# Altium schematic sheet RX_sys.SchDoc(RX_sys)
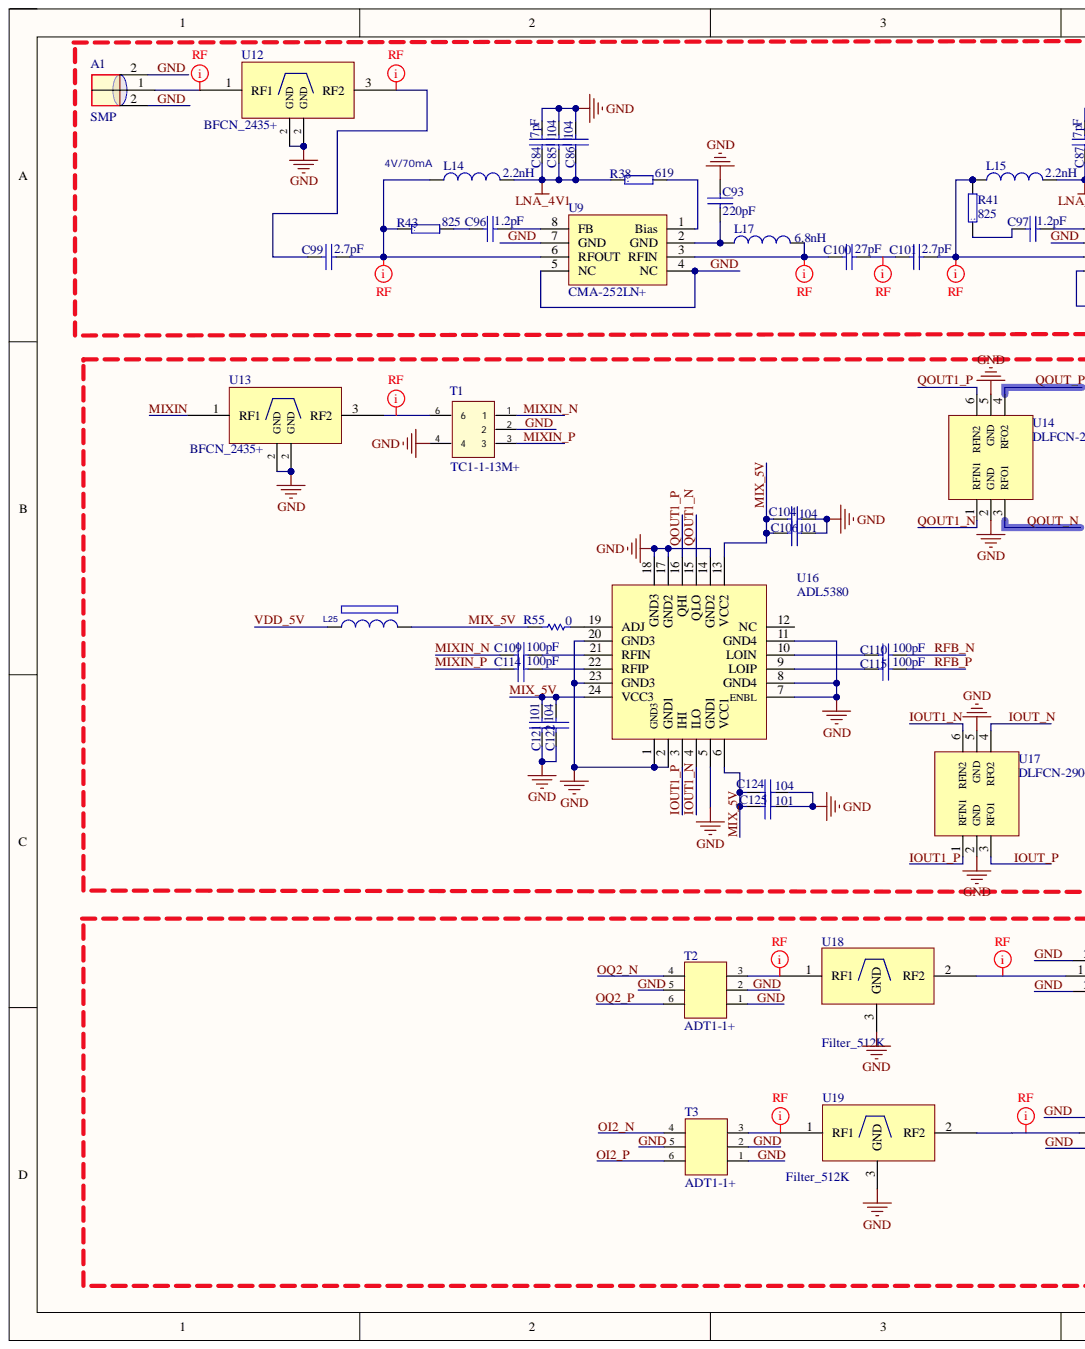
[diagram p0: RX_sys.SchDoc, left]
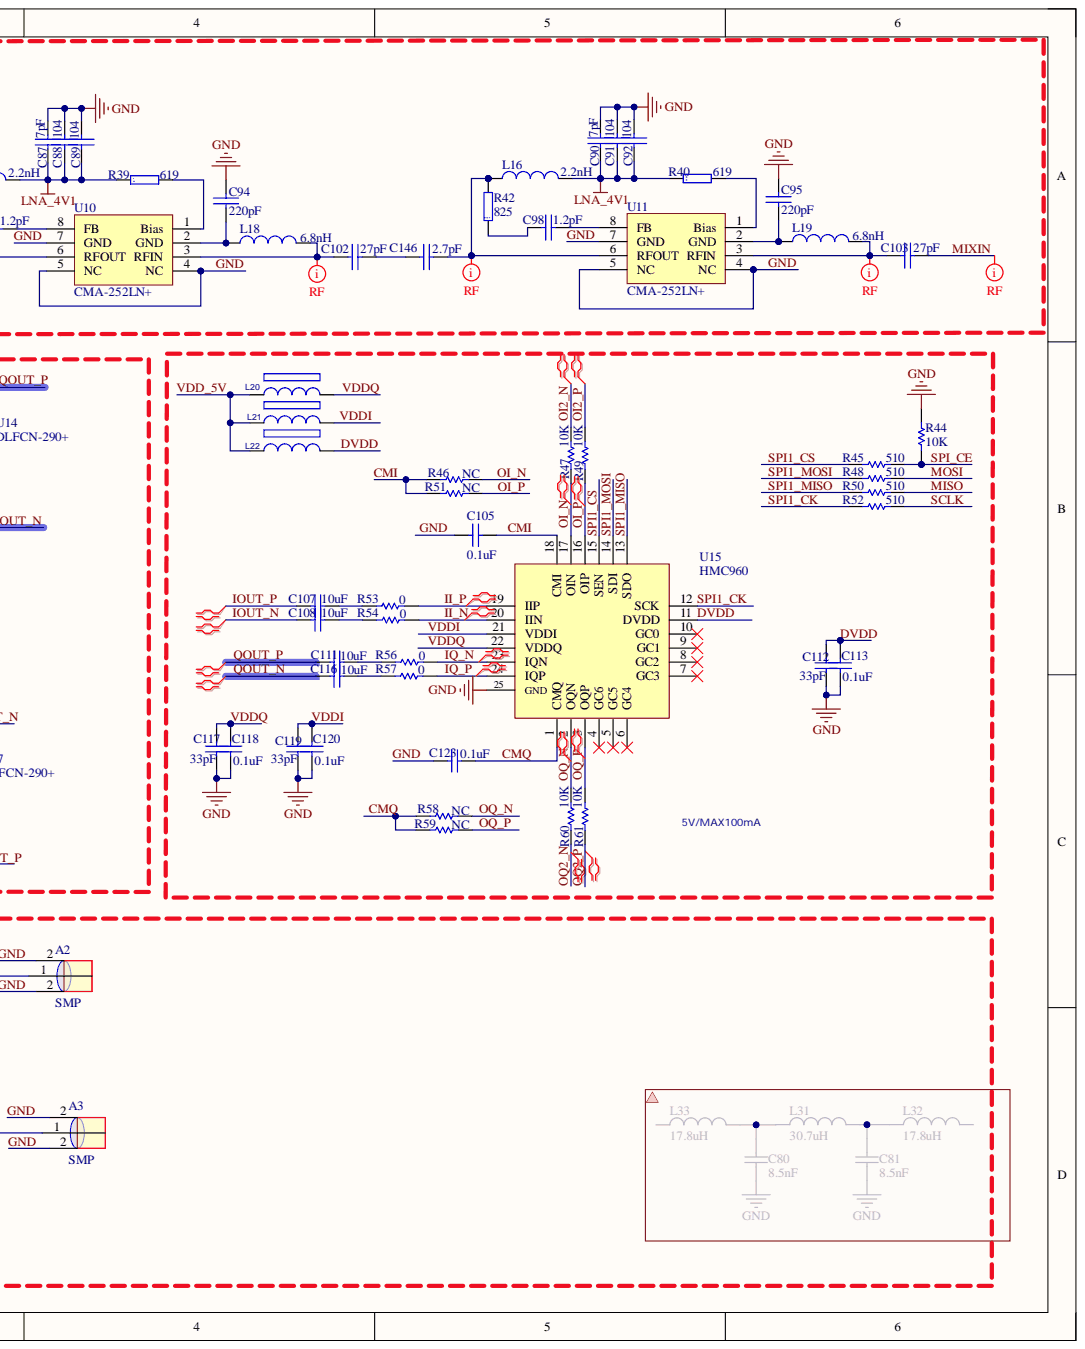
[diagram p1: RX_sys.SchDoc, right]

## Parts
A1  SMP  [SMA_3P]
A2  SMP  [SMA_3P]
A3  SMP  [SMA_3P]
C84  7pF  [0402C]
C85  104  [0402C]
C86  104  [0402C]
C87  7pF  [0402C]
C88  104  [0402C]
C89  104  [0402C]
C90  7pF  [0402C]
C91  104  [0402C]
C92  104  [0402C]
C93  220pF  [0402C]
C94  220pF  [0402C]
C95  220pF  [0402C]
C96  1.2pF  [0402C]
C97  1.2pF  [0402C]
C98  1.2pF  [0402C]
C99  2.7pF  [0402C]
C100  27pF  [0402C]
C101  2.7pF  [0402C]
C102  27pF  [0402C]
C103  27pF  [0402C]
C104  104  [0402C]
C105  0.1uF  [0402C]
C106  101  [0402C]  (value not drawn)
C107  10uF  [0402C]
C108  10uF  [0402C]
C109  100pF  [0402C]
C110  100pF  [0402C]
C111  10uF  [0402C]
C112  33pF  [0402C]
C113  0.1uF  [0402C]
C114  100pF  [0402C]
C115  100pF  [0402C]
C116  10uF  [0402C]
C117  33pF  [0402C]
C118  0.1uF  [0402C]
C119  33pF  [0402C]
C120  0.1uF  [0402C]
C121  101  [0402C]
C122  104  [0402C]
C123  0.1uF  [0402C]
C124  104  [0402C]
C125  101  [0402C]
C146  2.7pF  [0402C]
L14  2.2nH  [0402_L]
L15  2.2nH  [0402_L]
L16  2.2nH  [0402_L]
L17  6.8nH  [0402_L]
L18  6.8nH  [0402_L]
L19  6.8nH  [0402_L]
L20  BLM15HG102SN1D  [0603L]  (value not drawn)
L21  BLM15HG102SN1D  [0603L]  (value not drawn)
L22  BLM15HG102SN1D  [0603L]  (value not drawn)
L25  BLM15HG102SN1D  [0603L]  (value not drawn)
R38  619  [0402R]
R39  619  [0402R]
R40  619  [0402R]
R41  825  [0402R]
R42  825  [0402R]
R43  825  [0402R]
R44  10K  [0402R]
R45  510  [0402R]
R46  NC  [0402R]
R47  10K  [0402R]
R48  510  [0402R]
R49  10K  [0402R]
R50  510  [0402R]
R51  NC  [0402R]
R52  510  [0402R]
R53  0  [0402R]
R54  0  [0402R]
R55  0  [0402R]
R56  0  [0402R]
R57  0  [0402R]
R58  NC  [0402R]
R59  NC  [0402R]
R60  10K  [0402R]
R61  10K  [0402R]
T1  TC1-1-13M+  [TC1-1-43A+]
T2  ADT1-1+  [ADT1-1+]
T3  ADT1-1+  [ADT1-1+]
U9  CMA-252LN+  [CMA-252LN+]
U10  CMA-252LN+  [CMA-252LN+]
U11  CMA-252LN+  [CMA-252LN+]
U12  BFCN_2435+  [BFCN-2435+]
U13  BFCN_2435+  [BFCN-2435+]
U14  DLFCN-290+  [DLFCN-290]
U15  HMC960  [QFN24 _MMIC]
U16  ADL5380  [QFN24 _MMIC]
U17  DLFCN-290+  [DLFCN-290]
U18  Filter_512K  [Filter_512K]
U19  Filter_512K  [Filter_512K]

## Nets
LNA_4V1: C84.1 C85.2 C86.2 C87.1 C88.2 C89.2 C90.1 C91.2 C92.2 L14.2 L15.2 L16.2 R38.1 R39.1 R40.1
CMI: C105.1 R46.2 R51.2 U15.18(CMI)
CMQ: C123.1 R58.2 R59.2 U15.1(CMQ)
DVDD: C112.2 C113.2 L22.2 U15.11(DVDD)
GND: A1.2 A2.2 A3.2 C84.2 C85.1 C86.1 C87.2 C88.1 C89.1 C90.2 C91.1 C92.1 C93.2 C94.2 C95.2 C104.2 C105.2 C106.1 C112.1 C113.1 C117.1 C118.1 C119.1 C120.1 C121.1 C122.2 C123.2 C124.2 C125.1 R44.2 T1.2 T1.4 T2.1 T2.2 T2.5 T3.1 T3.2 T3.5 U9.4(NC) U9.5(NC) U9.7(GND) U10.4(NC) U10.5(NC) U10.7(GND) U11.4(NC) U11.5(NC) U11.7(GND) U12.2 U13.2 U14.2(GND) U14.5(GND) U15.25(GND) U16.1(GND3) U16.2(GND1) U16.5(GND1) U16.7(ENBL) U16.8(GND4) U16.11(GND4) U16.14(GND2) U16.17(GND2) U16.18(GND3) U16.20(GND3) U16.23(GND3) U17.2(GND) U17.5(GND) U18.3 U19.3
II_N: R54.2 U15.20(IIN)
II_P: R53.2 U15.19(IIP)
IOUT1_N: U16.4(ILO) U17.6(RFIN2)
IOUT1_P: U16.3(IHI) U17.1(RFIN1)
IOUT_N: C108.2 U17.4(RFO2)
IOUT_P: C107.2 U17.3(RFO1)
IQ_N: R56.2 U15.23(IQN)
IQ_P: R57.2 U15.24(IQP)
MISO: R50.2
MIX_5V: C104.1 C106.2 C121.2 C122.1 C124.1 C125.2 L25.2 R55.1 U16.6(VCC1) U16.13(VCC2) U16.24(VCC3)
MIXIN: C103.2 U13.1
MIXIN_N: C109.1 T1.1
MIXIN_P: C114.1 T1.3
MOSI: R48.2
OI2_N: R47.2 T3.4
OI2_P: R49.2 T3.6
OI_N: R46.1 R47.1 U15.17(OIN)
OI_P: R49.1 R51.1 U15.16(OIP)
OQ2_N: R60.1 T2.4
OQ2_P: R61.1 T2.6
OQ_N: R58.1 R60.2 U15.2(OQN)
OQ_P: R59.1 U15.3(OQP)
QOUT1_N: U14.1(RFIN1) U16.15(QLO)
QOUT1_P: U14.6(RFIN2) U16.16(QHI)
QOUT_N: C116.2 U14.3(RFO1)
QOUT_P: C111.2 U14.4(RFO2)
RFB_N: C110.2
RFB_P: C115.2
SCLK: R52.2
SPI1_CK: R52.1 U15.12(SCK)
SPI1_CS: R45.1 U15.15(SEN)
SPI1_MISO: R50.1 U15.13(SDO)
SPI1_MOSI: R48.1 U15.14(SDI)
SPI_CE: R44.1 R45.2
VDD_5V: L20.1 L21.1 L22.1 L25.1
VDDI: C119.2 C120.2 L21.2 U15.21(VDDI)
VDDQ: C117.2 C118.2 L20.2 U15.22(VDDQ)
NetA1_1: A1.1 U12.1
NetA2_1: A2.1 U18.2
NetA3_1: A3.1 U19.2
NetC93_1: C93.1 L17.1 U9.2(GND)
NetC94_1: C94.1 L18.1 U10.2(GND)
NetC95_1: C95.1 L19.1 U11.2(GND)
NetC96_1: C96.1 U9.8(FB)
NetC96_2: C96.2 R43.2
NetC97_1: C97.1 U10.8(FB)
NetC97_2: C97.2 R41.1
NetC98_1: C98.1 U11.8(FB)
NetC98_2: C98.2 R42.1
NetC99_1: C99.1 U12.3
NetC99_2: C99.2 L14.1 R43.1 U9.6(RFOUT)
NetC100_1: C100.1 L17.2 U9.3(RFIN)
NetC100_2: C100.2 C101.1
NetC101_2: C101.2 L15.1 R41.2 U10.6(RFOUT)
NetC102_1: C102.1 L18.2 U10.3(RFIN)
NetC102_2: C102.2 C146.1
NetC103_1: C103.1 L19.2 U11.3(RFIN)
NetC107_1: C107.1 R53.1
NetC108_1: C108.1 R54.1
NetC109_2: C109.2 U16.21(RFIN)
NetC110_1: C110.1 U16.10(LOIN)
NetC111_1: C111.1 R56.1
NetC114_2: C114.2 U16.22(RFIP)
NetC115_1: C115.1 U16.9(LOIP)
NetC116_1: C116.1 R57.1
NetC146_2: C146.2 L16.1 R42.2 U11.6(RFOUT)
NetR38_2: R38.2 U9.1(Bias)
NetR39_2: R39.2 U10.1(Bias)
NetR40_2: R40.2 U11.1(Bias)
NetR55_2: R55.2 U16.19(ADJ)
NetT1_6: T1.6 U13.3
NetT2_3: T2.3 U18.1
NetT3_3: T3.3 U19.1

Not connected: R61 2; U15 4(GC6) 5(GC5) 6(GC4) 7(GC3) 8(GC2) 9(GC1) 10(GC0); U16 12(NC)

## Library data of the parts
A1-A3 = SMP: GNSS; Component Kind: Standard; Library Name: TR_LIB.SchLib
C84-C104 C106 C109 C114 C121 C122 C124 C125 C146 = Cap: Component Kind: Standard; Library Name: iDste_A.SCHLIB
C105 C107 C108 C111-C113 C116-C120 C123 = Cap: GNSS; Value: 100pF; Component Kind: Standard; LatestRevisionDate: 17-Jul-2002; LatestRevisionNote: Re-released for DXP Platform.; Library Name: GPS_L1_L2.SCHLIB; PackageDescription: Radial Cap, Thru-Hole; 2 Leads; 0.3 in Pin Spacing; PackageReference: RAD-0.3; Published: 8-Jun-2000; Signal Integrity: Cap; Simulation: CAP; Publisher: Altium Limited
C110 C115 = Cap: GNSS; Component Kind: Standard; LatestRevisionDate: 17-Jul-2002; LatestRevisionNote: Re-released for DXP Platform.; Library Name: GPS_Ant.SCHLIB; PackageDescription: Radial Cap, Thru-Hole; 2 Leads; 0.3 in Pin Spacing; PackageReference: RAD-0.3; Published: 8-Jun-2000; Signal Integrity: Cap; Simulation: CAP; Value: 100pF; Publisher: Altium Limited
L14-L19 = Inductor: Code_IEC: 1005; Value: 10mH; Code_JEITA: 0402; Component Kind: Standard; Description: Inductor; LatestRevisionDate: 29-May-2009; LatestRevisionNote: IPC-7351 Footprint Added.; Library Name: Miscellaneous Devices.IntLib; PackageDescription: Chip Inductor; PackageReference: 0402-A; Published: 8-Jun-2000; Signal Integrity: Inductor; Simulation: INDUCTOR; Publisher: Altium Limited
L20-L22 L25 = L: GNSS; Bottom: 40; Right: 20; Component Kind: Standard
R38-R43 = RES: Component Kind: Standard; Library Name: iDste_A.SCHLIB
R44-R61 = Res1: GNSS; Component Kind: Standard; LatestRevisionDate: 17-Jul-2002; LatestRevisionNote: Re-released for DXP Platform.; Library Name: GPS_L1_L2.SCHLIB; PackageDescription: Axial Device, Thru-Hole; 2 Leads; 0.3 in Pin Spacing; PackageReference: AXIAL-0.3; Published: 8-Jun-2000; Signal Integrity: Res; Simulation: RESISTOR; Publisher: Altium Limited
T1 = TC1-1-43+: GNSS; Component Kind: Standard; Library Name: GPS_Ant.SCHLIB; PCB DECAL: TC1-1-43A+
T2 T3 = ADT1-1+: Component Kind: Standard; Library Name: TR_LIB.SchLib
U9-U11 = CMA-252LN+: Component Kind: Standard; Library Name: TR_LIB.SchLib
U12 U13 = BFCN_2435+: Component Kind: Standard; Library Name: iDste_A.SCHLIB
U14 U17 = DLFCN-290+: Component Kind: Standard; Library Name: TR_LIB.SchLib
U15 = HMC960: Component Kind: Standard; Library Name: TR_LIB.SchLib
U16 = ADL5380: Component Kind: Standard; Library Name: TR_LIB.SchLib
U18 U19 = Filter_512K: Component Kind: Standard; Library Name: TR_LIB.SchLib
